# Altium schematic sheet USB.SchDoc(USB)
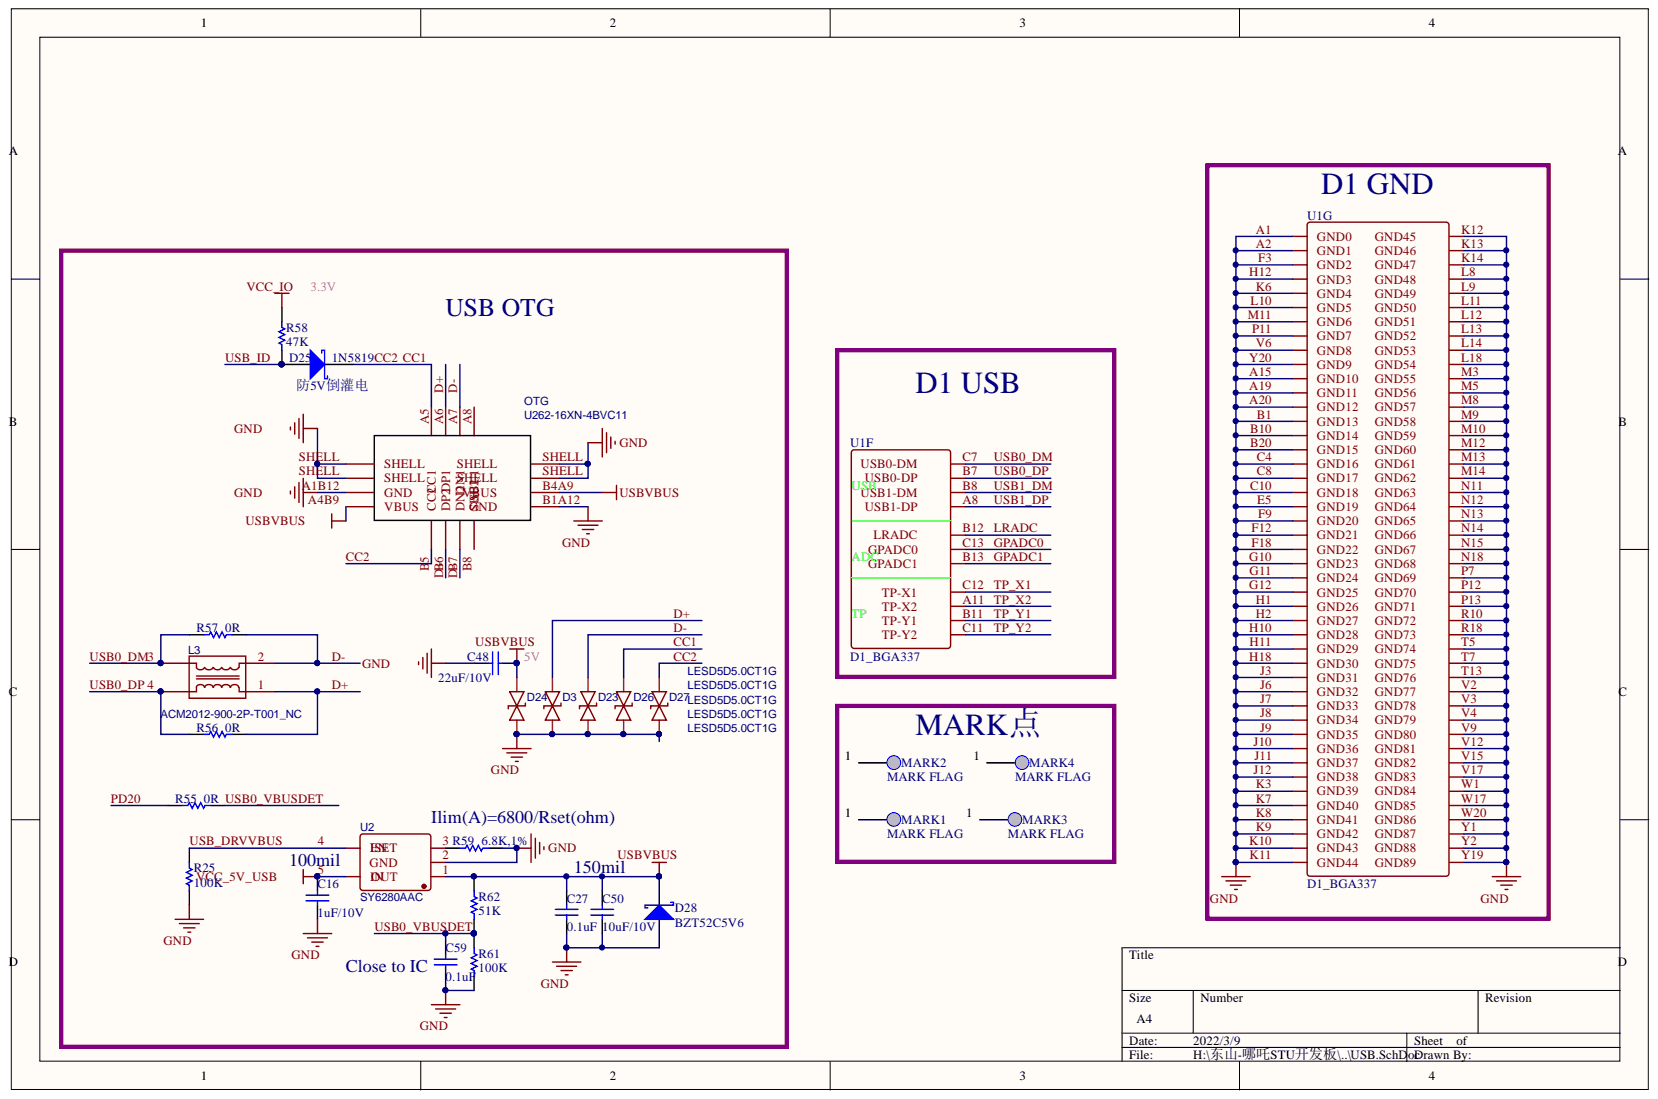
[diagram p0: USB.SchDoc]

## Parts
C16  1uF/10V  [0402]
C27  0.1uF  [0402]
C48  22uF/10V  [0603]
C50  10uF/10V  [0603]
C59  0.1uF  [0402]
D3  LESD5D5.0CT1G  [SOD-523_L1.2-W0.8-LS1.6-BI]
D23  LESD5D5.0CT1G  [SOD-523_L1.2-W0.8-LS1.6-BI]
D24  LESD5D5.0CT1G  [SOD-523_L1.2-W0.8-LS1.6-BI]
D25  1N5819  [SOD-123_L2.8-W1.8-LS3.7-RD]
D26  LESD5D5.0CT1G  [SOD-523_L1.2-W0.8-LS1.6-BI]
D27  LESD5D5.0CT1G  [SOD-523_L1.2-W0.8-LS1.6-BI]
D28  BZT52C5V6  [SOD-123_L2.8-W1.8-LS3.7-RD]
L3  ACM2012-900-2P-T001_NC  [FILTER-SMD_4P-L2.0-W1.2-BL]
MARK1  MARK FLAG  [MARK_1MM]
MARK2  MARK FLAG  [MARK_1MM]
MARK3  MARK FLAG  [MARK_1MM]
MARK4  MARK FLAG  [MARK_1MM]
OTG  U262-16XN-4BVC11  [TYPEC-12PIN - duplicate]
R25  100K  [0402]
R55  0R  [0402]
R56  0R  [0402]
R57  0R  [0402]
R58  47K  [0402]
R59  6.8K,1%  [0402]
R61  100K  [0402]
R62  51K  [0402]
U1  D1_BGA337  (parts F,G)
U2  SY6280AAC  [SOT-23-5_L3.0-W1.7-P0.95-LS2.8-BR]

## Nets
GND: C16.1 C27.2 C48.1 C50.1 C59.2 D3.1 D23.1 D24.1 D26.1 D27.1 D28.1 OTG.A1B12(GND) OTG.B1A12 OTG.SHELL R25.1 R59.1 R61.1 U1.A1(GND0) U1.A2(GND1) U1.A15(GND10) U1.A19(GND11) U1.A20(GND12) U1.B1(GND13) U1.B10(GND14) U1.B20(GND15) U1.C4(GND16) U1.C8(GND17) U1.C10(GND18) U1.E5(GND19) U1.F3(GND2) U1.F9(GND20) U1.F12(GND21) U1.F18(GND22) U1.G10(GND23) U1.G11(GND24) U1.G12(GND25) U1.H1(GND26) U1.H2(GND27) U1.H10(GND28) U1.H11(GND29) U1.H12(GND3) U1.H18(GND30) U1.J3(GND31) U1.J6(GND32) U1.J7(GND33) U1.J8(GND34) U1.J9(GND35) U1.J10(GND36) U1.J11(GND37) U1.J12(GND38) U1.K3(GND39) U1.K6(GND4) U1.K7(GND40) U1.K8(GND41) U1.K9(GND42) U1.K10(GND43) U1.K11(GND44) U1.K12 U1.K13 U1.K14 U1.L8 U1.L9 U1.L10(GND5) U1.L11 U1.L12 U1.L13 U1.L14 U1.L18 U1.M3 U1.M5 U1.M8 U1.M9 U1.M10 U1.M11(GND6) U1.M12 U1.M13 U1.M14 U1.N11 U1.N12 U1.N13 U1.N14 U1.N15 U1.N18 U1.P7 U1.P11(GND7) U1.P12 U1.P13 U1.R10 U1.R18 U1.T5 U1.T7 U1.T13 U1.V2 U1.V3 U1.V4 U1.V6(GND8) U1.V9 U1.V12 U1.V15 U1.V17 U1.W1 U1.W17 U1.W20 U1.Y1 U1.Y2 U1.Y19 U1.Y20(GND9) U2.2
USBVBUS: C27.1 C48.2 C50.2 D24.2 D28.2 OTG.A4B9(VBUS) OTG.B4A9 R62.2 U2.1
VCC_5V_USB: C16.2 U2.5(IN)
VCC_IO: R58.2
CC1: D25.2 D26.2 D27.2 OTG.A5 OTG.B5(CC2)
D+: D3.2 L3.1 OTG.A6 OTG.B6(DP2) R56.1
D-: D23.2 L3.2 OTG.A7 OTG.B7(DN2) R57.1
GPADC0: U1.C13
GPADC1: U1.B13
LRADC: U1.B12
PD20: R55.2
TP_X1: U1.C12
TP_X2: U1.A11
TP_Y1: U1.B11
TP_Y2: U1.C11
USB0_DM: L3.3 R57.2 U1.C7
USB0_DP: L3.4 R56.2 U1.B7
USB0_VBUSDET: C59.1 R55.1 R61.2 R62.1
USB1_DM: U1.B8
USB1_DP: U1.A8
USB_DRVVBUS: R25.2 U2.4(ISET)
USB_ID: D25.1 R58.1
NetR59_2: R59.2 U2.3

Not connected: MARK1 1; MARK2 1; MARK3 1; MARK4 1; OTG A8 B8(SBU2)

## Library data of the parts
C16 C27 C48 C50 C59 = Cap: Capacitor; PartNumber: CAP100NF; Component Kind: Standard; LatestRevisionDate: 17-Jul-2002; LatestRevisionNote: Re-released for DXP Platform.; Library Name: Miscellaneous Devices.IntLib; PackageReference: 0402; Published: 8-Jun-2000; Signal Integrity: Cap; Simulation: CAP; Publisher: Altium Limited
  C16: Value: 1uF/10V
  C27 C59: Value: 0.1uF
  C48: Value: 22uF/10V
  C50: Value: 10uF/10V
D3 D23 D24 D26 D27 = LESD5D5.0CT1G: BOM_Manufacturer: Leshan Radio; Contributor: LCSC; BOM_JLCPCB Part Class: Extended Part; BOM_Manufacturer Part: LESD5D5.0CT1G; BOM_Supplier: LCSC; BOM_Supplier Part: C383211; Component Kind: Standard; spicePre: D; spiceSymbolName: LESD5D5.0CT1G
D25 D28 = D Schottky: Schottky Diode; Code_JEDEC: DO-214-AA; Component Kind: Standard; LatestRevisionDate: 17-Jul-2002; LatestRevisionNote: Re-released for DXP Platform.; Library Name: Miscellaneous Devices.IntLib; PackageDescription: DO-214-AA/SMB; 2 C-Bend Leads; Body 5.3 x 3.6 mm, inc. leads (LxW); PackageReference: SMB; Published: 8-Jun-2000; Signal Integrity: D Schottky; Simulation: SKYDIODE; Publisher: Altium Limited
  D25: Value: 1N5819
  D28: Value: BZT52C5V6
L3 = ACM2012-900-2P-T001: BOM_Manufacturer: TDK; Contributor: LCSC; BOM_JLCPCB Part Class: Extended Part; BOM_Manufacturer Part: ACM2012-900-2P-T001; BOM_Supplier: LCSC; BOM_Supplier Part: C307642; Component Kind: Standard; spicePre: L; spiceSymbolName: ACM2012-900-2P-T001
MARK1-MARK4 = TP: Component Kind: Standard; Library Name: HM2.SchLib; Value: MARK FLAG
OTG = U262-16XN-4BVC11: BOM_Manufacturer: XKB Enterprise; Contributor: LCSC; BOM_Manufacturer Part: U262-16XN-4BVC11; BOM_Supplier: LCSC; BOM_Supplier Part: C319148; Component Kind: Standard; Library Name: HM2.SchLib; spicePre: U; spiceSymbolName: U262-16XN-4BVC11
R25 R58 R61 R62 = Res1: Resistor; PartNumber: RES22R; Component Kind: Standard; LatestRevisionDate: 17-Jul-2002; LatestRevisionNote: Re-released for DXP Platform.; Library Name: Miscellaneous Devices.IntLib; PackageReference: 0402; Published: 8-Jun-2000; Signal Integrity: Res; Simulation: RESISTOR; Publisher: Altium Limited
  R25 R61: Value: 100K
  R58: Value: 47K
  R62: Value: 51K
R55-R57 R59 = Res1: Resistor; PartNumber: RES2.2K; Component Kind: Standard; LatestRevisionDate: 17-Jul-2002; LatestRevisionNote: Re-released for DXP Platform.; Library Name: Miscellaneous Devices.IntLib; PackageReference: 0402; Published: 8-Jun-2000; Signal Integrity: Res; Simulation: RESISTOR; Publisher: Altium Limited
  R55-R57: Value: 0R
  R59: Value: 6.8K,1%
U2 = SY6280AAC: BOM_Manufacturer: Silergy; BOM_JLCPCB Part Class: Extended Part; BOM_Manufacturer Part: SY6280AAC; BOM_Supplier: LCSC; BOM_Supplier Part: C55136; Component Kind: Standard; Library Name: HM2.SchLib; spicePre: U; spiceSymbolName: SY6280AAC
U1 = D1_BGA337: Component Kind: Standard; Library Name: HM2.SchLib
